# Altium schematic sheet Transceiver.SchDoc(Transceiver)
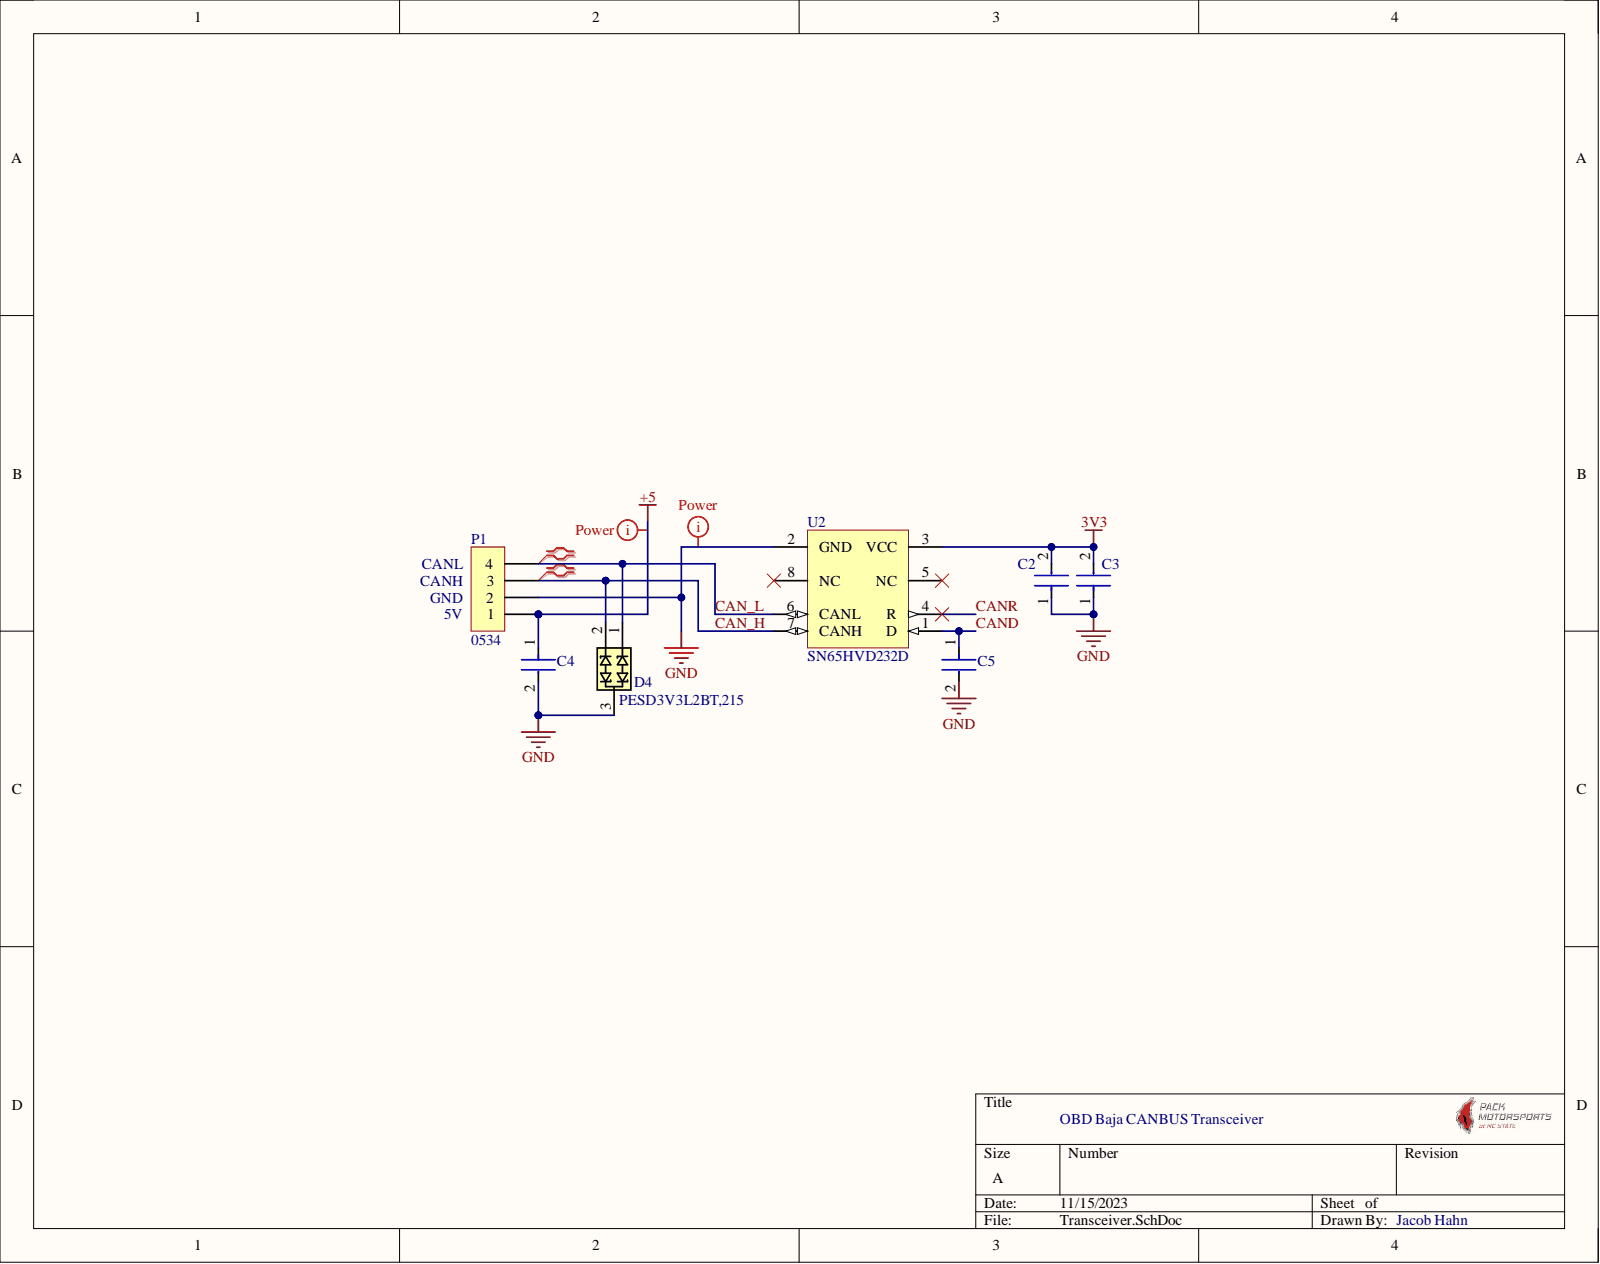
[diagram p0: Transceiver.SchDoc]

## Parts
C2  CL21B104KACNNNC  (value not drawn)
C3  CL21B104KACNNNC  (value not drawn)
C4  CL21A106KOQNNNE  (value not drawn)
C5  CL21B103KAANNNC  (value not drawn)
D4  PESD3V3L2BT,215
P1  0534
U2  SN65HVD232D  [SN65HVD232]

## Nets
3V3: C2.2 C3.2 U2.3(VCC)
+5: C4.1 P1.1
GND: C2.1 C3.1 C4.2 C5.2 D4.3 P1.2 U2.2(GND)
CAN_H: D4.2 P1.3 U2.7(CANH)
CAN_L: D4.1 P1.4 U2.6(CANL)
CAND: C5.1 U2.1(D)
CANR: U2.4(R)

Not connected: U2 5(NC) 8(NC)

## Library data of the parts
C2 C3 = CMP-13271-002854-1: CL21 Series 0805 100nF 25V ±10% Tolerance X7R Multilayer Ceramic Chip Capacitor; Capacitance: 100nF; Case Code (Imperial): 0805; Case Code (Metric): 2012; Case/Package: 0805; Component Kind: Standard; Contact Plating: Tin; Depth: 1.25mm; Dielectric: X7R; Height: 0.85mm; Length: 2mm; Library Name: Altium Content Vault; Max Operating Temperature: 125°C; Min Operating Temperature: -55°C; Mount: Surface Mount; Package Quantity: 1; Packaging: Tape and Reel; Radiation Hardening: No; Thickness: 0.037inch; Tolerance: 10%; Voltage: 25V; Voltage Rating: 25V; Width: 0.049inch
C4 = CMP-2000-06102-2: CL21 Series 0805 10uF 16V ±10% Tolerance X5R Multilayer Ceramic Chip Capacitor; Dielectric: X7R; Capacitance: 10uF; Case Code (Imperial): 0805; Case Code (Metric): 2012; Case/Package: 0805; Component Kind: Standard; Depth: 1.25mm; Height: 1.25mm; Lead Free: Lead Free; Length: 2mm; Library Name: Altium Content Vault; Max Operating Temperature: 85°C; Min Operating Temperature: -55°C; Mount: Surface Mount; Package Quantity: 1; Packaging: Tape and Reel; Radiation Hardening: No; Thickness: 0.055inch; Tolerance: 10%; Voltage: 16V; Voltage Rating: 16V; Voltage Rating (DC): 16V; Width: 0.049inch
C5 = CMP-13271-004188-1: CL21 Series 0805 10nF 25V ±10% Tolerance X7R Multilayer Ceramic Chip Capacitor; Tolerance: 20%; Voltage Rating: 50V; Capacitance: 10nF; Case Code (Imperial): 0805; Case Code (Metric): 2012; Case/Package: 0805; Component Kind: Standard; Depth: 1.25mm; Dielectric: X7R; Height: 0.65mm; Length: 2mm; Library Name: Altium Content Vault; Max Operating Temperature: 125°C; Min Operating Temperature: -55°C; Mount: Surface Mount; Package Quantity: 1; Packaging: Tape and Reel; Radiation Hardening: No; Thickness: 0.03inch; Voltage Rating (DC): 50V; Width: 0.049inch
D4 = CMP-00002-6: PESD3V3L2BT - Low capacitance double bidirectional ESD protection diode in SOT23; Component Kind: Standard; Library Name: Pack Motorsports Baja; RoHS Compliant: Yes
P1 = CMP-00000-3: 2.50mm Pitch Mini-Lock Wire-to-Board Header Right Angle Friction and Positive Lock 4 Circuits; Connector Type: Male Pin; Contact Resistance: 20mR; Number of Contacts: 4; Pitch: 2.5mm; Color: White; Component Kind: Standard; Contact Material: Bronze; Contact Mating Length: 0.157inch; Contact Plating: Tin; Contact Type: Male Pin; Depth: 11.5mm; Fastening Type: Ramp; Housing Color: White; Housing Material: Polybutylene; Length: 12.4mm; Library Name: Pack Motorsports Baja; Max Operating Temperature: 105°C; Min Operating Temperature: -40°C; Mount: Through Hole; Number of Positions: 4; Number of Rows: 1; Orientation: Right Angle; Packaging: Tray; Radiation Hardening: No; Series: Mini-Lock™ 53426; Termination: Solder
U2 = CMP-0315-00073-2: 3.3 V CAN Transceiver, 17 mA, -40 to 85 degC, 8-pin SOIC (D), Green (RoHS & no Sb/Br); Manufacturer: Texas Instruments; Drivers Per Package: 1; ICC(Max)(mA): 17; Rating: Catalog; Supply Voltage(s)(V): 3.3; Transient Pulse Protection(V): -25 to 25; Code_JEDEC: MS-012AA; Component Kind: Standard; ComponentLink1Description: Datasheet; ComponentLink1URL: http://www.ti.com/general/docs/lit/getliterature.tsp?genericPartNumber=SN65HVD232&fileType=pdf; DatasheetVersion: SLOS346K; Eco-Plan: Green (RoHS & no Sb/Br); Isolated: No; Library Name: Altium Content Vault; Mounting Technology: Surface Mount; PackageDescription: 8-Pin Plastic Small Outline Integrated Circuit, 4.9 x 3.9 mm, Pitch 1.27 mm Pitch; PackageReference: D0008A; PackageVersion: revM, Jun-2011; PartNumber: SN65HVD232D; Receivers Per Package: 1; SubFamily: CAN
